# Altium schematic sheet Power.SchDoc(Power)
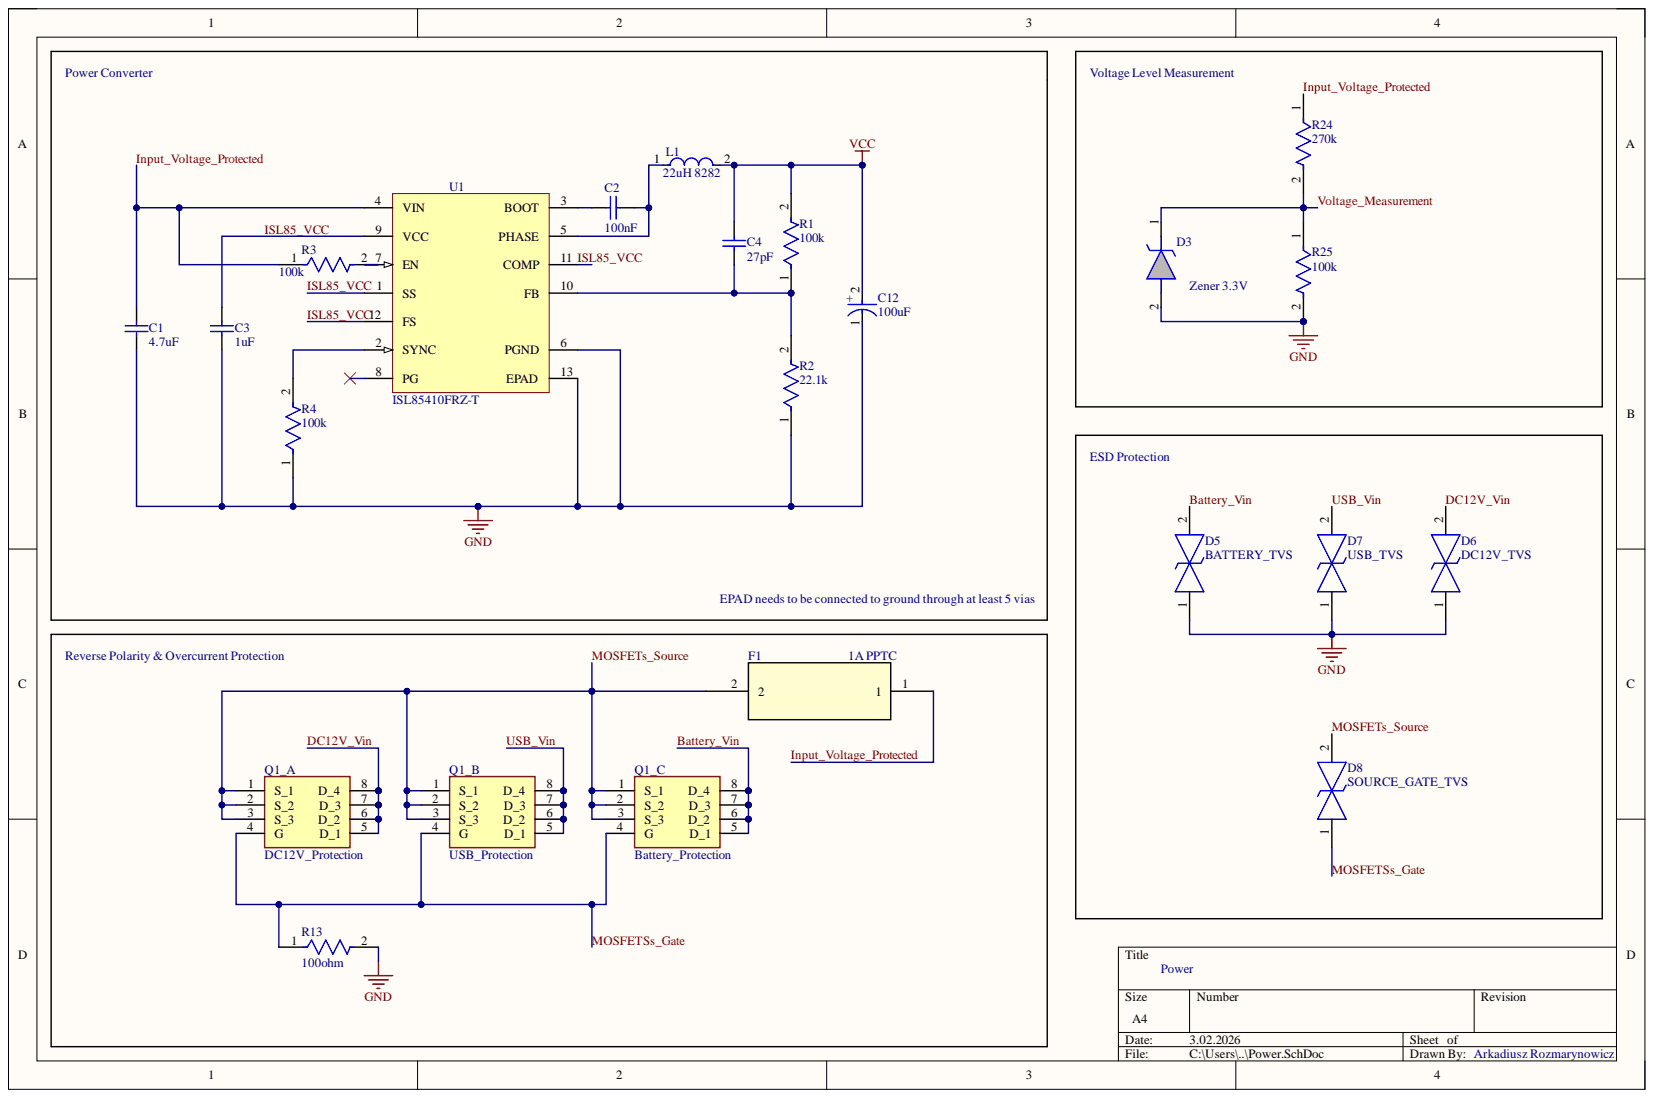
[diagram p0: Power.SchDoc]

## Parts
C1  4.7uF  [CAPC2013X145X48NL20T23]
C2  100nF  [CAPC2013X145X48NL20T23]
C3  1uF  [CAPC2013X145X48NL20T23]
C4  27pF  [CAPC2013X145X48NL20T23]
C12  100uF  [FP-MAL214097001E3-MFG]
D3  Zener 3.3V  [ZMM33B]
D5  BATTERY_TVS  [DIONM5127X250N]
D6  DC12V_TVS  [DIONM5127X250N]
D7  USB_TVS  [DIONM5127X250N]
D8  SOURCE_GATE_TVS  [DIONM5127X250N]
F1  1A PPTC  [CHIP_ 0603L_1P8X1_LTF]
L1  22uH 8282  Inductance: 22uH  [FP-IHLP-3232DZ-FP_2_413x5-MFG]
Q1_A  DC12V_Protection  [SOIC127P600X170-8N]
Q1_B  USB_Protection  [SOIC127P600X170-8N]
Q1_C  Battery_Protection  [SOIC127P600X170-8N]
R1  100k  [FP-CRGCQ0805-IPC_C]
R2  22.1k  [FP-CRGCQ0805-IPC_C]
R3  100k  [FP-CRGCQ0805-IPC_C]
R4  100k  [FP-CRGCQ0805-IPC_C]
R13  100ohm  [FP-CRGCQ0805-IPC_C]
R24  270k  [FP-CRGCQ0805-IPC_C]
R25  100k  [FP-CRGCQ0805-IPC_C]
U1  ISL85410FRZ-T  [L12_4x3_MFG]

## Nets
GND: C1.1 C3.1 C12.1 D3.2 D5.1 D6.1 D7.1 R2.1 R4.1 R13.2 R25.2 U1.6(PGND) U1.13(EPAD)
VCC: C4.2 C12.2 L1.2 R1.2
Battery_Vin: D5.2 Q1_C.5(D_1) Q1_C.6(D_2) Q1_C.7(D_3) Q1_C.8(D_4)
DC12V_Vin: D6.2 Q1_A.5(D_1) Q1_A.6(D_2) Q1_A.7(D_3) Q1_A.8(D_4)
Input_Voltage_Protected: C1.2 F1.1 R3.1 R24.1 U1.4(VIN)
ISL85_VCC: C3.2 U1.1(SS) U1.9(VCC) U1.11(COMP) U1.12(FS)
MOSFETs_Source: D8.2 F1.2 Q1_A.1(S_1) Q1_A.2(S_2) Q1_A.3(S_3) Q1_B.1(S_1) Q1_B.2(S_2) Q1_B.3(S_3) Q1_C.1(S_1) Q1_C.2(S_2) Q1_C.3(S_3)
MOSFETSs_Gate: D8.1 Q1_A.4(G) Q1_B.4(G) Q1_C.4(G) R13.1
USB_Vin: D7.2 Q1_B.5(D_1) Q1_B.6(D_2) Q1_B.7(D_3) Q1_B.8(D_4)
Voltage_Measurement: D3.1 R24.2 R25.1
NetC2_1: C2.1 L1.1 U1.5(PHASE)
NetC2_2: C2.2 U1.3(BOOT)
NetC4_1: C4.1 R1.1 R2.2 U1.10(FB)
NetR3_2: R3.2 U1.7(EN)
NetR4_2: R4.2 U1.2(SYNC)

Not connected: U1 8(PG)

## Library data of the parts
C1-C4 = CMP-2007-01705-1: Manufacturer: Vitramon; Part Number: VJ0805Y104KXAMR; Component Kind: Standard; Library Name: Altium Content Vault
C12 = CMP-00030-44337467-1: MAL214097001E3 Radial Can - SMD ALUMINUM ELECTROLYTIC CAPACITOR 140CRH 35V 100muF ±20% 0.394Dia 10.00mm; Capacitance: 100µF; Dielectric Material: Aluminium; Impedance: 250mΩ; Life (Hours): 1500hours; Polarity: Polar; Ripple Current: 255mA; Case/Package: Radial; Component Kind: Standard; Diameter: 10mm; Height: 10mm; Height - Seated (Max): 10.4902mm; Library Name: Altium Content Vault; Max Operating Temperature: 125°C; Min Operating Temperature: -55°C; Mount: PCB, Surface Mount; NexarPartId: 44337467; Number of Pins: 2; Packaging: Cut Tape; Radiation Hardening: No; REACH SVHC: Unknown; Ripple Current (AC): 255mA; RoHS: Compliant; Termination: SMD/SMT; Tolerance: 20%; Voltage Rating: 35V; Voltage Rating (AC): 35V; Voltage Rating (DC): 35V; Width: 10mm
D3 = ZMM33B: SMD Planra Zener Diode; Manufacturer_Name: Diotec; Geometry.Height: 1.45mm; Arrow Part Number: ZMM33B; Arrow Price/Stock: https://www.arrow.com/en/products/zmm33b/diotec-semiconductor-ag?utm_currency=USD&region=nac; Component Kind: Standard; Library Name: ZMM33B.IntLib; Manufacturer_Part_Number: ZMM33B; Mouser Part Number: 637-ZMM33B; Mouser Price/Stock: https://www.mouser.co.uk/ProductDetail/Diotec-Semiconductor/ZMM33B?qs=OlC7AqGiEDlS5hTI7j6ASg%3D%3D
D5-D8 = SMAJ12CA-HP6AT_13: 19.9V Clamp 30.2A Ipp Tvs Diode Surface Mount DO-214AC (SMA); Manufacturer_Name: YAGEO; Manufacturer_Part_Number: SMAJ12CA-HP6AT/13; Geometry.Height: 2.5mm; Component Kind: Standard; Datasheet Link: https://www.yageo.com/upload/media/product/productsearch/datasheet/cpc/tvs/SMAJ-HP6AT_1.pdf; Library Name: SMAJ12CA-HP6AT_13.IntLib
F1 = 0603L100SLYR: PPTC; Manufacturer_Name: Littelfuse Inc; Component Kind: Standard; Library Name: 0603L100SLYR.IntLib; Manufacturer_Part_Number: 0603L100SLYR; RefDes: RefDes; Type: Type; Copyright: Copyright (C) 2025 Ultra Librarian. All rights reserved.
L1 = CMP-02424-000385-1: Commercial Inductors, High Temperature (155 °C) Series 22uH 3.7A 110.21mΩ 20%; DC Resistance (DCR): 110.21mR; Max DC Current: 3.8A; Self Resonant Frequency: 9MHz; Shielding: Shielded; Test Frequency: 100kHz; Case/Package: 3232; Component Kind: Standard; Current Rating: 3.7A; Depth: 8.26mm; Height: 4mm; Height - Seated (Max): 0.158inch; Lead Free: Lead Free; Length: 0.322inch; Library Name: Altium Content Vault; Max Operating Temperature: 155°C; Military Standard: Not; Min Operating Temperature: -55°C; Mount: Surface Mount; Package Quantity: 500; Packaging: Tape and Reel; Saturation Current: 3.8A; Termination: SMD/SMT; Tolerance: 20%; Width: 0.322inch
Q1_A Q1_B Q1_C = DMP3056LSS-13: MOSFET P Channel 30V 7.1A 2.1V @ 250uA 45m @ 6A,10V SOP-8_150mil RoHS; Manufacturer_Name: Diodes Incorporated; Geometry.Height: 1.7mm; Arrow Part Number: DMP3056LSS-13; Arrow Price/Stock: https://www.arrow.com/en/products/dmp3056lss-13/diodes-incorporated?region=asia; Component Kind: Standard; Datasheet Link: https://www.diodes.com//assets/Datasheets/ds31421.pdf; Library Name: DMP3056LSS-13.IntLib; Manufacturer_Part_Number: DMP3056LSS-13; Mouser Part Number: 621-DMP3056LSS-13; Mouser Price/Stock: https://www.mouser.co.uk/ProductDetail/Diodes-Incorporated/DMP3056LSS-13?qs=oUsD4qhOtFyEnPLZKitYRA%3D%3D
R1-R4 = CMP-03211-009742-1: CRGCQ0805 100KΩ 0.125W ±100ppm/°C 5% 150V; Manufacturer: TE Connectivity; Manufacturer Part Number: CRGCQ0805J100K; Tolerance: 0.05; Ambient Temperature Range High: +70°C; Automotive: Yes; Automotive Grade: Grade 1142; Category: Res; Component Kind: Standard; ComponentLink2Description: Datasheet; ComponentLink2URL: https://www.te.com/commerce/DocumentDelivery/DDEController?Action=showdoc&DocId=Data+Sheet%7F1773204-3%7F1%7Fpdf%7FEnglish%7FENG_DS_1773204-3_1.pdf%7F4-2176341-1151; Composition: Thick Film; Device Class L1: Passive Components; Device Class L2: Resistors; Device Class L3: Chip SMD Resistors; Height: 0.65mm; Ipc Land Pattern Name: RESC200125X55; Lead Free: Yes; Library Name: Altium Content Vault; Octopart: https://octopart.com/crgcq0805j100k-te+connectivity-91018199; Package: CRGCQ0805; Power Rating: 0.125W; RoHS: TRUE; Series: CRGCQ; Temperature: -55°C to +155°C; Temperature Coefficient: ±100ppm/°C; Temperature Range High: +155°C; Temperature Range Low: -55°C; Voltage Rating: 150V
  R1 R3 R4: Resistance: 100KΩ; Value: 100KΩ
  R2: Resistance: 22.1k; Value: 22.1k
R13 = CMP-03211-009742-1: CRGCQ0805 10KΩ 0.125W ±100ppm/°C 5% 150V; Manufacturer: TE Connectivity; Manufacturer Part Number: CRGCQ0805J100K; Tolerance: 0.05; Ambient Temperature Range High: +70°C; Automotive: Yes; Automotive Grade: Grade 1142; Category: Res; Component Kind: Standard; ComponentLink2Description: Datasheet; ComponentLink2URL: https://www.te.com/commerce/DocumentDelivery/DDEController?Action=showdoc&DocId=Data+Sheet%7F1773204-3%7F1%7Fpdf%7FEnglish%7FENG_DS_1773204-3_1.pdf%7F4-2176341-1151; Composition: Thick Film; Device Class L1: Passive Components; Device Class L2: Resistors; Device Class L3: Chip SMD Resistors; Height: 0.65mm; Ipc Land Pattern Name: RESC200125X55; Lead Free: Yes; Library Name: Altium Content Vault; Octopart: https://octopart.com/crgcq0805j100k-te+connectivity-91018199; Package: CRGCQ0805; Power Rating: 0.125W; Resistance: 100ohm; RoHS: TRUE; Series: CRGCQ; Temperature: -55°C to +155°C; Temperature Coefficient: ±100ppm/°C; Temperature Range High: +155°C; Temperature Range Low: -55°C; Value: 100ohm; Voltage Rating: 150V
R24 R25 = CMP-03211-009742-1: CRGCQ0805 10KΩ 0.125W ±100ppm/°C 5% 150V; Manufacturer: TE Connectivity; Manufacturer Part Number: CRGCQ0805J100K; Resistance: 100ohm; Tolerance: 0.05; Value: 100ohm; Ambient Temperature Range High: +70°C; Automotive: Yes; Automotive Grade: Grade 1142; Category: Res; Component Kind: Standard; ComponentLink2Description: Datasheet; ComponentLink2URL: https://www.te.com/commerce/DocumentDelivery/DDEController?Action=showdoc&DocId=Data+Sheet%7F1773204-3%7F1%7Fpdf%7FEnglish%7FENG_DS_1773204-3_1.pdf%7F4-2176341-1151; Composition: Thick Film; Device Class L1: Passive Components; Device Class L2: Resistors; Device Class L3: Chip SMD Resistors; Height: 0.65mm; Ipc Land Pattern Name: RESC200125X55; Lead Free: Yes; Library Name: Altium Content Vault; Octopart: https://octopart.com/crgcq0805j100k-te+connectivity-91018199; Package: CRGCQ0805; Power Rating: 0.125W; RoHS: TRUE; Series: CRGCQ; Temperature: -55°C to +155°C; Temperature Coefficient: ±100ppm/°C; Temperature Range High: +155°C; Temperature Range Low: -55°C; Voltage Rating: 150V
U1 = CMP-00572-88255842-3: Inverter RoHS Compliant Adjustable Positive Switching Regulator IC 125C 2MHz 1A 82.298666mg; Manufacturer Lifecycle Status: ACTIVE; Efficiency: 94%; Max Input Voltage: 40V; Max Junction Temperature (Tj): 125°C; Max Output Voltage: 34V; Min Input Voltage: 3V; Min Output Voltage: 600mV; Number of Outputs: 1; Operating Supply Current: 4µA; Operating Supply Voltage: 36V; Pitch: 500µm; Quiescent Current: 80µA; RAM Size: 12kB; Sync/Async: Synchronous; Synchronous Rectifier: Yes; Topology: Buck; Case/Package: DFN; Component Kind: Standard; ComponentLink1Description: Manufacturer's Website; ComponentLink1URL: http://www.renesas.com; ComponentLink2Description: Datasheet URL; ComponentLink2URL: https://octopart.com/cad-content/api/get-model-datasheet/15189/88255842; ComponentLink3Description: Manufacturer's Package Drawing; ComponentLink3URL: https://www.renesas.com/en/document/psc/l124x3-12-lead-dual-flat-no-lead-plastic-package?r=568491; Export Control Classification Number (ECCN) Code: EAR99; Height: 900µm; HelpURL: https://octopart.com/cad-content/api/get-model-datasheet/15189/88255842; Lead Free: Lead Free; Length: 4mm; Library Name: Altium Content Vault; Lifecycle Status: Production; Logic Function: Inverter; Max Operating Temperature: 125°C; Max Output Current: 1A; Max Supply Voltage: 40V; Min Operating Temperature: -40°C; Min Supply Voltage: 3V; Mount: Surface Mount; Number of Pins: 12; Output Configuration: Positive; Output Current: 1A; Output Type: Adjustable; Output Voltage: 34V; Packaging: Tape & Reel; Radiation Hardening: No; RoHS: Compliant; Switching Frequency: 2MHz; Weight: 82.298666mg; Width: 3mm
